# Altium schematic sheet GPS.SchDoc(GPS)
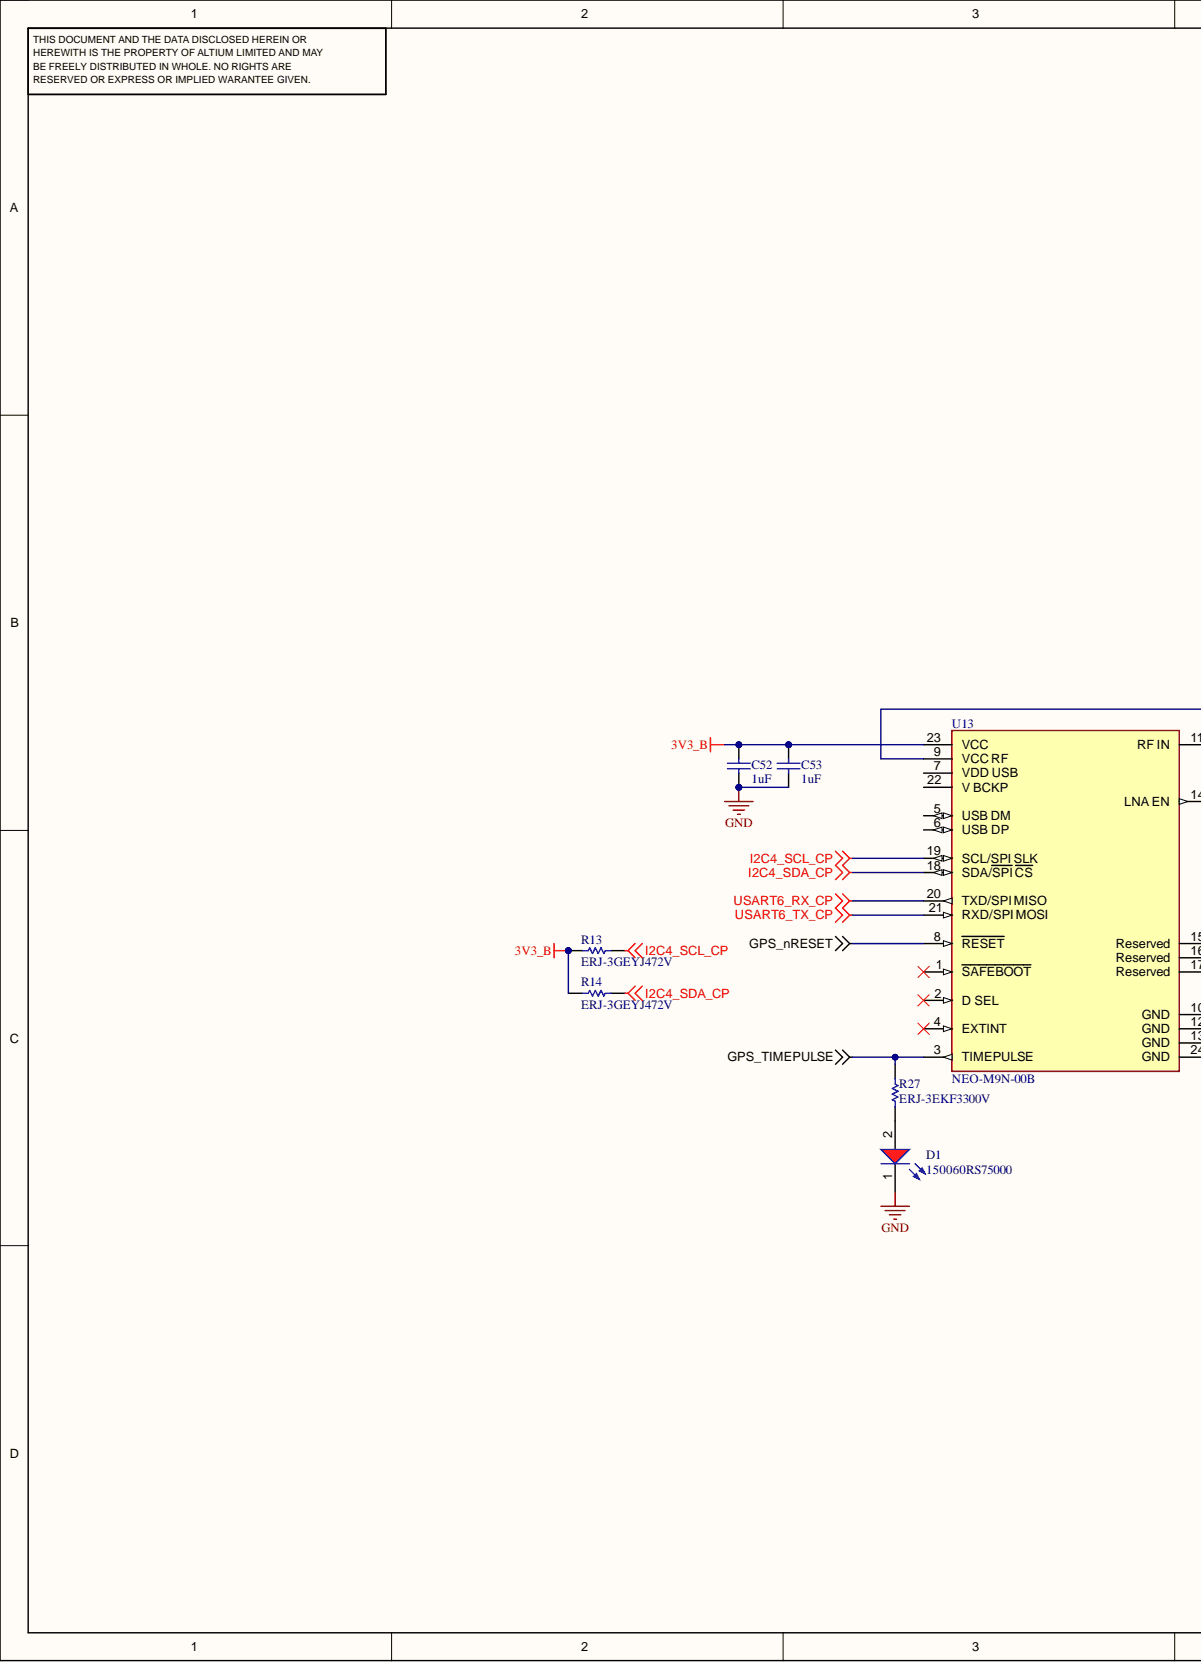
[diagram p0: GPS.SchDoc, left]
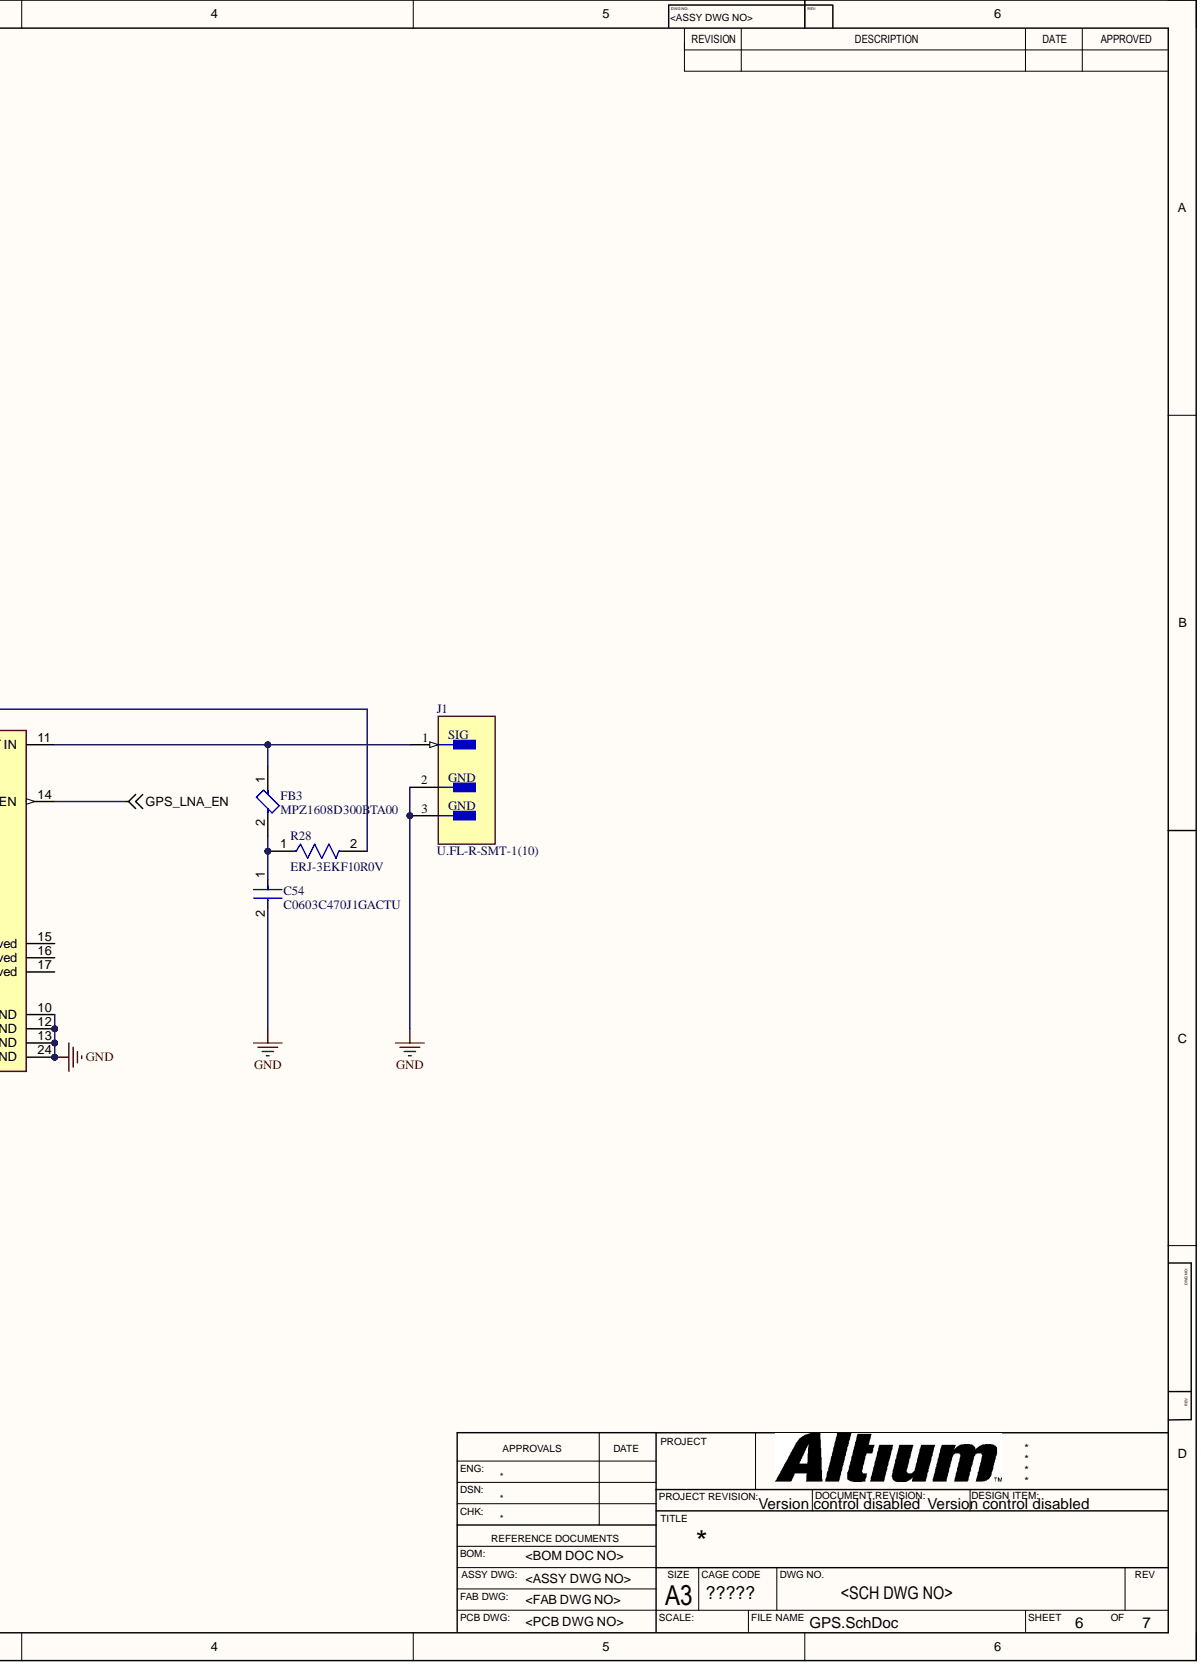
[diagram p1: GPS.SchDoc, right]

## Parts
C52  1uF
C53  1uF
C54  C0603C470J1GACTU
D1  150060RS75000
FB3  MPZ1608D300BTA00
J1  U.FL-R-SMT-1(10)
R13  ERJ-3GEYJ472V
R14  ERJ-3GEYJ472V
R27  ERJ-3EKF3300V
R28  ERJ-3EKF10R0V
U13  NEO-M9N-00B

## Nets
3V3_B: C52.2 C53.2 R13.1 R14.1 U13.23(VCC)
GND: C52.1 C53.1 C54.2 D1.1 J1.2 J1.3 U13.10(GND) U13.12(GND) U13.13(GND) U13.24(GND)
GPS_LNA_EN: U13.14(LNA_EN)
GPS_nRESET: U13.8(RESET)
GPS_TIMEPULSE: R27.1 U13.3(TIMEPULSE)
I2C4_SCL_CP: R13.2 U13.19(SCL/SPI_SLK)
I2C4_SDA_CP: R14.2 U13.18(SDA/SPI_CS)
USART6_RX_CP: U13.20(TXD/SPI_MISO)
USART6_TX_CP: U13.21(RXD/SPI_MOSI)
NetC54_1: C54.1 FB3.2 R28.1
NetD1_2: D1.2 R27.2
NetFB3_1: FB3.1 J1.1 U13.11(RF_IN)
NetR28_2: R28.2 U13.9(VCC_RF)

Not connected: U13 1(SAFEBOOT) 2(D_SEL) 4(EXTINT) 5(USB_DM) 6(USB_DP) 7(VDD_USB) 15(Reserved) 16(Reserved) 17(Reserved) 22(V_BCKP)

## Library data of the parts
C52 C53 = CMP-2006-02298-2: Manufacturer: Kemet; Part Number: C0603C105K4RACTU; Component Kind: Standard; Library Name: Altium Content Vault
C54 = CMP-2006-03321-2: CAP CER 47PF 100V 5% NP0 0603; Manufacturer: KEMET; Automotive Grade: Grade 1; Material: Ceramic; Temperature Characteristic: C0G; Temperature Coefficient: 30ppm/°C; Tolerance: 0.05; Automotive: Yes; Capacitance: 47 pF; Category: Cap; Component Kind: Standard; ComponentLink2Description: Datasheet; ComponentLink2URL: https://api.kemet.com/component-edge/download/datasheet/C0603C100J5GACAUTO.pdf; Device Class L1: Passive Components; Device Class L2: Capacitors; Device Class L3: Ceramic Capacitors; Digikey Description: CAP CER 47PF 100V 5% NP0 0603; Height: 0.87mm; Lead Free: Yes; Library Name: Altium Content Vault; Manufacturer Part Number: C0603C470J1GACTU; Mouser Description: Multilayer Ceramic Capacitors MLCC - SMD/SMT 100volts 47pF C0G 5%; Octopart: https://octopart.com/c0603c470j1gactu-kemet-3768219; Package: C0603C-CF; RoHS: TRUE; Temperature: -55°C to +125°C; Temperature Range High: +125°C; Temperature Range Low: -55°C; Value: 47 pF; Voltage Rating: 100 V
D1 = CMP-1426-00001-4: LED Low-Power Uni-Color Red 630nm 2V 2-Pin Chip 0603(1608Metric) T/R; Dominant Wavelength: 625nm; Forward Current: 100mA; Lens Style: Clear, Rectangular; Luminous Intensity: 250mcd; Max Junction Temperature (Tj): 95°C; Max Reverse Voltage (DC): 5V; Power Dissipation: 72mW; Test Current: 20mA; Viewing Angle: 140°; Case Code (Imperial): 0603; Case Code (Metric): 1608; Case/Package: 0603; Color: Red; Component Kind: Standard; Forward Voltage: 2V; Height: 700µm; Length: 1.6mm; Library Name: Altium Content Vault; Max Operating Temperature: 85°C; Min Operating Temperature: -40°C; Mount: Surface Mount; Packaging: Tape and Reel; Peak Wavelength: 630nm; REACH SVHC: Unknown; Reverse Voltage: 5V; RoHS: Compliant; Wavelength: 625nm; Width: 800µm
FB3 = CMP-08257-000221-1: FERRITE BEAD 30 OHM 0603 1LN; Current Rating: 1.8A; DC Resistance (DCR): 60mR; Impedance: 30R; Case Code (Imperial): 0603; Case Code (Metric): 1608; Case/Package: 0603; Component Kind: Standard; Core Material: Ferrite; Height: 0.037inch; Length: 0.063inch; Library Name: Altium Content Vault; Max DC Current: 1.8A; Mount: Surface Mount; Package Quantity: 4000; Packaging: Tape and Reel; RoHS Compliant: Yes; Series: MPZ; Width: 0.031inch
J1 = CMP-2000-05152-3: CONN U.FL RCPT STR 50 OHM SMD; Body Material: Bronze; Body Plating: Silver; Connector Type: Coaxial; Dielectric Material: Polymer; Frequency: 6GHz; Gender: Male; Impedance: 50R; Insulation Resistance: 500MR; Component Kind: Standard; Contact Material: Brass; Contact Plating: Gold; Depth: 3.1mm; Fastening Type: Snap; Housing Color: Beige; Lead Free: Lead Free; Length: 3mm; Library Name: Altium Content Vault; Max Frequency: 6GHz; Max Operating Temperature: 90°C; Min Operating Temperature: -40°C; Mount: Surface Mount; Orientation: Straight; Package Quantity: 2500; Packaging: Cut Tape; Radiation Hardening: No; REACH SVHC: No SVHC; Series: U.FL; Termination: Solder; Weight: 0.001764oz; Wire/Cable Type: Coaxial
R13 R14 = CMP-2000-00796-1: Manufacturer: Panasonic; Component Kind: Standard; Library Name: Altium Content Vault; Part Number: ERJ-3GEYJ472V
R27 = CMP-2000-00435-2: Chip Resistor, 330 Ohm, +/- 1%, 0.1 W, -55 to 155 degC, 0603 (1608 Metric), RoHS, Tape and Reel; Current Rating: 500 mA; Gender: Male; Mating Cycles: 10000; Voltage Rating: 10 V; Component Kind: Standard; ComponentLink1Description: Manufacturer URL; ComponentLink1URL: http://www.panasonic.com/; ComponentLink2Description: Datasheet; ComponentLink2URL: http://industrial.panasonic.com/cdbs/www-data/pdf/RDA0000/AOA0000C86.pdf; Contact Finish: Gold; Contact Material: Copper; Contact Plating: Gold; DatasheetVersion: Apr-15; Height: 1.45 mm; Lead Pitch: 1.1 mm; Length: 13.7 mm; Library Name: Altium Content Vault; Max Operating Temperature: 85 degC; Min Operating Temperature: -25 degC; Mount: Surface Mount; Package Quantity: 1500; PackageDescription: 2-Pin Surface Mount Device, Body 1.6 x 0.85 mm; PackageReference: 0603; Packaging: Tape and Reel; Pins: 8; REACH SVHC: No SVHC; RoHSCompliant: true; Width: 1.45 mm
R28 = CMP-2000-00195-2: RES SMD 10 OHM 1% 1/10W 0603; Manufacturer: Panasonic; Automotive Grade: Grade 0; Power: 100mW; Power Rating: 0.1W; Resistance: 10Ω; Temperature Coefficient: 100ppm/°C; Value: 10Ω; Voltage Rating: 75V; Automotive: Yes; Category: Res; Component Kind: Standard; ComponentLink2Description: Datasheet; ComponentLink2URL: https://b2b-api.panasonic.eu/file_stream/pids/fileversion/1263; Composition: Thick Film; Device Class L1: Passive Components; Device Class L2: Resistors; Device Class L3: Chip SMD Resistors; Digikey Description: RES SMD 10 OHM 1% 1/10W 0603; Footprint Url: https://industrial.panasonic.com/cdbs/www-data/pdf/RDA0000/DMA0000COL17.pdf; Height: 0.55mm; Ipc Land Pattern Name: RESC16080X45; Lead Free: Yes; Library Name: Altium Content Vault; Manufacturer Part Number: ERJ-3EKF10R0V; Octopart: https://octopart.com/erj-3ekf10r0v-panasonic-55403649; Package: ERJ3EK; RoHS: TRUE; Series: ERJ; Temperature: -55°C to +155°C; Temperature Range High: +155°C; Temperature Range Low: -55°C; Tolerance: 1%
U13 = CMP-207130-000006-2: M9 standard precision module; Manufacturer: u-blox; Interface: I2C,SPI,UART,USB; Max Supply Voltage: 3.6V; Min Supply Voltage: 1.65V; Sensitivity: -167dBm; Automotive: No; Category: UNK; Component Kind: Standard; ComponentLink2Description: Datasheet; ComponentLink2URL: https://www.u-blox.com/sites/default/files/NEO-M9N_DataSheet_%28UBX-19014285%29.pdf; Device Class L1: Integrated Circuits (ICs); Device Class L2: RF Semiconductors and Devices; Device Class L3: RF Receivers; Footprint Url: https://www.u-blox.com/sites/default/files/NEO-M9N_Integrationmanual_%28UBX-19014286%29.pdf; Height: 2.6mm; Lead Free: Yes; Library Name: Altium Content Vault; Manufacturer Part Number: NEO-M9N-00B; Octopart: https://octopart.com/neo-m9n-00b-u-blox-107315722; Package: 0; RoHS: TRUE; Temperature: -40°C to +85°C; Temperature Range High: +85°C; Temperature Range Low: -40°C
